# Altium schematic sheet Sheet2.SchDoc
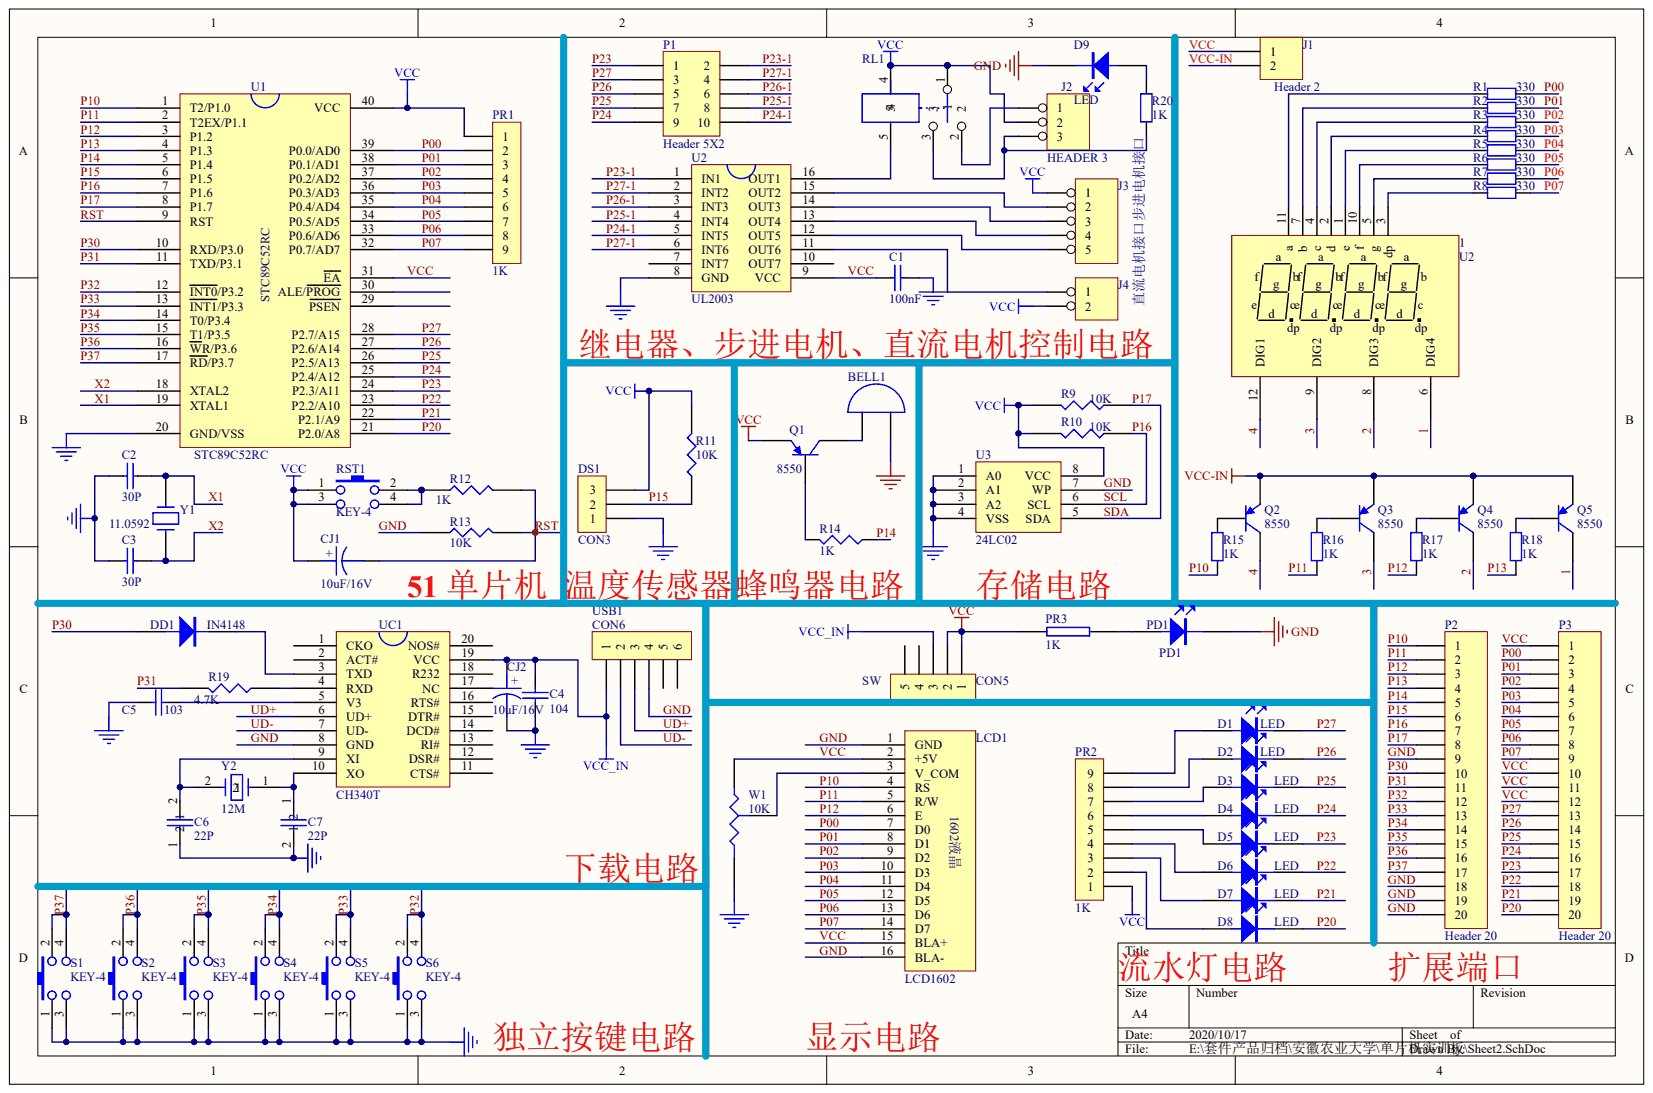
[diagram p0: Sheet2.SchDoc]

## Parts
1  U2
BELL1  (no value)
C1  100nF
C2  30P
C3  30P
C4  104
C5  103
C6  22P
C7  22P
CJ1  10uF/16V
CJ2  10uF/16V
D1  LED
D2  LED
D3  LED
D4  LED
D5  LED
D6  LED
D7  LED
D8  LED
D9  LED
DD1  IN4148
DS1  CON3
J1  Header 2
J2  HEADER 3
J3  (no value)
J4  (no value)
LCD1  LCD1602
P1  Header 5X2
P2  Header 20
P3  Header 20
PD1  PD1
PR1  1K
PR2  1K
PR3  1K
Q1  8550
Q2  8550
Q3  8550
Q4  8550
Q5  8550
R1  value 330  (hidden comment: Res2)
R2  value 330  (hidden comment: Res2)
R3  value 330  (hidden comment: Res2)
R4  value 330  (hidden comment: Res2)
R5  value 330  (hidden comment: Res2)
R6  value 330  (hidden comment: Res2)
R7  value 330  (hidden comment: Res2)
R8  value 330  (hidden comment: Res2)
R9  10K
R10  10K
R11  10K
R12  1K
R13  10K
R14  1K
R15  value 1K  (hidden comment: Res2)
R16  value 1K  (hidden comment: Res2)
R17  value 1K  (hidden comment: Res2)
R18  value 1K  (hidden comment: Res2)
R19  4.7K
R20  value 1K  (hidden comment: Res2)
RL1  (no value)
RST1  KEY-4
S1  KEY-4
S2  KEY-4
S3  KEY-4
S4  KEY-4
S5  KEY-4
S6  KEY-4
SW  CON5
U1  STC89C52RC
U2  UL2003
U3  24LC02
UC1  CH340T
USB1  CON6
W1  10K
Y1  11.0592
Y2  12M

## Nets
VCC_IN: C4.1 CJ2.1 SW.3 UC1.19(VCC) USB1.1
1: 1.6(DIG4) Q5.3
2: 1.8(DIG3_dp) Q4.3
3: 1.9(DIG2) Q3.3
4: 1.12(DIG1) Q2.3
GND: BELL1.2 C1.1 C2.2 C3.2 C4.2 C5.1 C6.1 C7.2 CJ2.2 D9.2 DS1.1 LCD1.1(GND) LCD1.16(BLA-) P2.9 P2.18 P2.19 P2.20 PD1.2 R13.1 S1.1 S1.3 S2.1 S2.3 S3.1 S3.3 S4.1 S4.3 S5.1 S5.3 S6.1 S6.3 U1.20(GND/VSS) U2.8(GND) U3.1(A0) U3.2(A1) U3.3(A2) U3.4(VSS) U3.7(WP) UC1.8(GND) USB1.4 W1.3
P00: LCD1.7(D0) P3.2 PR1.2 R1.1 U1.39(P0.0/AD0)
P01: LCD1.8(D1) P3.3 PR1.3 R2.1 U1.38(P0.1/AD1)
P02: LCD1.9(D2) P3.4 PR1.4 R3.1 U1.37(P0.2/AD2)
P03: LCD1.10(D3) P3.5 PR1.5 R4.1 U1.36(P0.3/AD3)
P04: LCD1.11(D4) P3.6 PR1.6 R5.1 U1.35(P0.4/AD4)
P05: LCD1.12(D5) P3.7 PR1.7 R6.1 U1.34(P0.5/AD5)
P06: LCD1.13(D6) P3.8 PR1.8 R7.1 U1.33(P0.6/AD6)
P07: LCD1.14(D7) P3.9 PR1.9 R8.1 U1.32(P0.7/AD7)
P10: LCD1.4(RS) P2.1 R15.1 U1.1(T2/P1.0)
P11: LCD1.5(R/W) P2.2 R16.1 U1.2(T2EX/P1.1)
P12: LCD1.6(E) P2.3 R17.1 U1.3(P1.2)
P13: P2.4 R18.1 U1.4(P1.3)
P14: P2.5 R14.1 U1.5(P1.4)
P15: DS1.2 P2.6 R11.1 U1.6(P1.5)
P16: P2.7 R10.1 U1.7(P1.6) U3.6(SCL)
P17: P2.8 R9.1 U1.8(P1.7) U3.5(SDA)
P20: D8.2 P3.20 U1.21(P2.0/A8)
P21: D7.2 P3.19 U1.22(P2.1/A9)
P22: D6.2 P3.18 U1.23(P2.2/A10)
P23: D5.2 P1.1 P3.17 U1.24(P2.3/A11)
P23-1: P1.2 U2.1(IN1)
P24: D4.2 P1.9 P3.16 U1.25(P2.4/A12)
P24-1: P1.10 U2.5(INT5)
P25: D3.2 P1.7 P3.15 U1.26(P2.5/A13)
P25-1: P1.8 U2.4(INT4)
P26: D2.2 P1.5 P3.14 U1.27(P2.6/A14)
P26-1: P1.6 U2.3(INT3)
P27: D1.2 P1.3 P3.13 U1.28(P2.7/A15)
P27-1: P1.4 U2.2(INT2) U2.6(INT6)
P30: DD1.1 P2.10 U1.10(RXD/P3.0)
P31: P2.11 R19.2 U1.11(TXD/P3.1)
P32: P2.12 S6.2 S6.4 U1.12(INT0/P3.2)
P33: P2.13 S5.2 S5.4 U1.13(INT1/P3.3)
P34: P2.14 S4.2 S4.4 U1.14(T0/P3.4)
P35: P2.15 S3.2 S3.4 U1.15(T1/P3.5)
P36: P2.16 S2.2 S2.4 U1.16(WR/P3.6)
P37: P2.17 S1.2 S1.4 U1.17(RD/P3.7)
RST: CJ1.2 R12.2 R13.2 U1.9(RST)
UD+: UC1.6(UD+) USB1.3
UD-: UC1.7(UD-) USB1.2
VCC: C1.2 CJ1.1 DS1.3 J1.1 J2.2 J3.1 J4.2 LCD1.2(+5V) LCD1.15(BLA+) P3.1 P3.10 P3.11 P3.12 PR1.1 PR2.1 PR3.2 Q1.2 R9.2 R10.2 R11.2 RL1.1 RL1.4 RST1.1 RST1.3 SW.1 SW.2 U1.31(EA) U1.40(VCC) U2.9(VCC) U3.8(VCC) W1.2
VCC-IN: J1.2 Q2.2 Q3.2 Q4.2 Q5.2
X1: C2.1 U1.19(XTAL1) Y1.2
X2: C3.1 U1.18(XTAL2) Y1.1
Net1_1: 1.1(e) R5.2
Net1_2: 1.2(d) R4.2
Net1_3: 1.3(dp) R8.2
Net1_4: 1.4(c) R3.2
Net1_5: 1.5(g) R7.2
Net1_7: 1.7(b) R2.2
Net1_10: 1.10(f) R6.2
Net1_11: 1.11(a) R1.2
NetBELL1_1: BELL1.1 Q1.3
NetC5_2: C5.2 UC1.5(V3)
NetC6_2: C6.2 UC1.9(XI) Y2.2
NetC7_1: C7.1 UC1.10(XO) Y2.1
NetD1_1: D1.1 PR2.9
NetD2_1: D2.1 PR2.8
NetD3_1: D3.1 PR2.7
NetD4_1: D4.1 PR2.6
NetD5_1: D5.1 PR2.5
NetD6_1: D6.1 PR2.4
NetD7_1: D7.1 PR2.3
NetD8_1: D8.1 PR2.2
NetD9_1: D9.1 R20.1
NetDD1_2: DD1.2 UC1.3(TXD)
NetJ2_1: J2.1 RL1.2
NetJ2_3: J2.3 R20.2 RL1.3
NetJ3_2: J3.2 U2.15(OUT2)
NetJ3_3: J3.3 U2.14(OUT3)
NetJ3_4: J3.4 U2.13(OUT4)
NetJ3_5: J3.5 U2.12(OUT5)
NetJ4_1: J4.1 U2.11(OUT6)
NetLCD1_3: LCD1.3(V_COM) W1.1
NetPD1_1: PD1.1 PR3.1
NetQ1_1: Q1.1 R14.2
NetQ2_1: Q2.1 R15.2
NetQ3_1: Q3.1 R16.2
NetQ4_1: Q4.1 R17.2
NetQ5_1: Q5.1 R18.2
NetR12_1: R12.1 RST1.2 RST1.4
NetR19_1: R19.1 UC1.4(RXD)
NetRL1_5: RL1.5 U2.16(OUT1)
N00006:

Not connected: SW 4 5; U1 29(PSEN) 30(ALE/PROG); U2 7(INT7) 10(OUT7); UC1 1(CKO) 2(ACT#) 11(CTS#) 12(DSR#) 13(RI#) 14(DCD#) 15(DTR#) 16(RTS#) 17(NC) 18(R232) 20(NOS#); USB1 5 6

## Library data of the parts
1 BELL1 C1-C7 CJ1 CJ2 D1-D9 DD1 DS1 J1-J4 LCD1 P1-P3 PD1 PR1-PR3 Q1-Q5 R1-R20 RL1 RST1 S1-S6 SW U1-U3 UC1 USB1 W1 Y1 Y2 = (no symbol name)
  J1 P1-P3: LatestRevisionDate: 17-Jul-2002; LatestRevisionNote: Re-released for DXP Platform.
  Q1-Q5: Published: 8-Jun-2000; LatestRevisionDate: 15-Jan-2003; LatestRevisionNote: PCB Footprint 'BCY-W3/D4.7' replaced; DatasheetDocument: 1997; PackageDocument: Sep-1998; PackageReference: TO-92A; Code_JEDEC: TO-92A; ComponentLink1Description: Manufacturer Link; ComponentLink1URL: http://www.fairchildsemi.com/; ComponentLink2Description: Datasheet; ComponentLink2URL: http://www.fairchildsemi.com/ds/2N%2F2N3906.pdf
  R1-R8 R15-R18 R20: Published: 8-Jun-2000; LatestRevisionDate: 17-Jul-2002; LatestRevisionNote: Re-released for DXP Platform.; PackageReference: AXIAL-0.4
  J1 P1-P3 Q1-Q5 R1-R8 R15-R18 R20: Publisher: Altium Limited
